# Altium schematic sheet Controller.SchDoc(Controller)
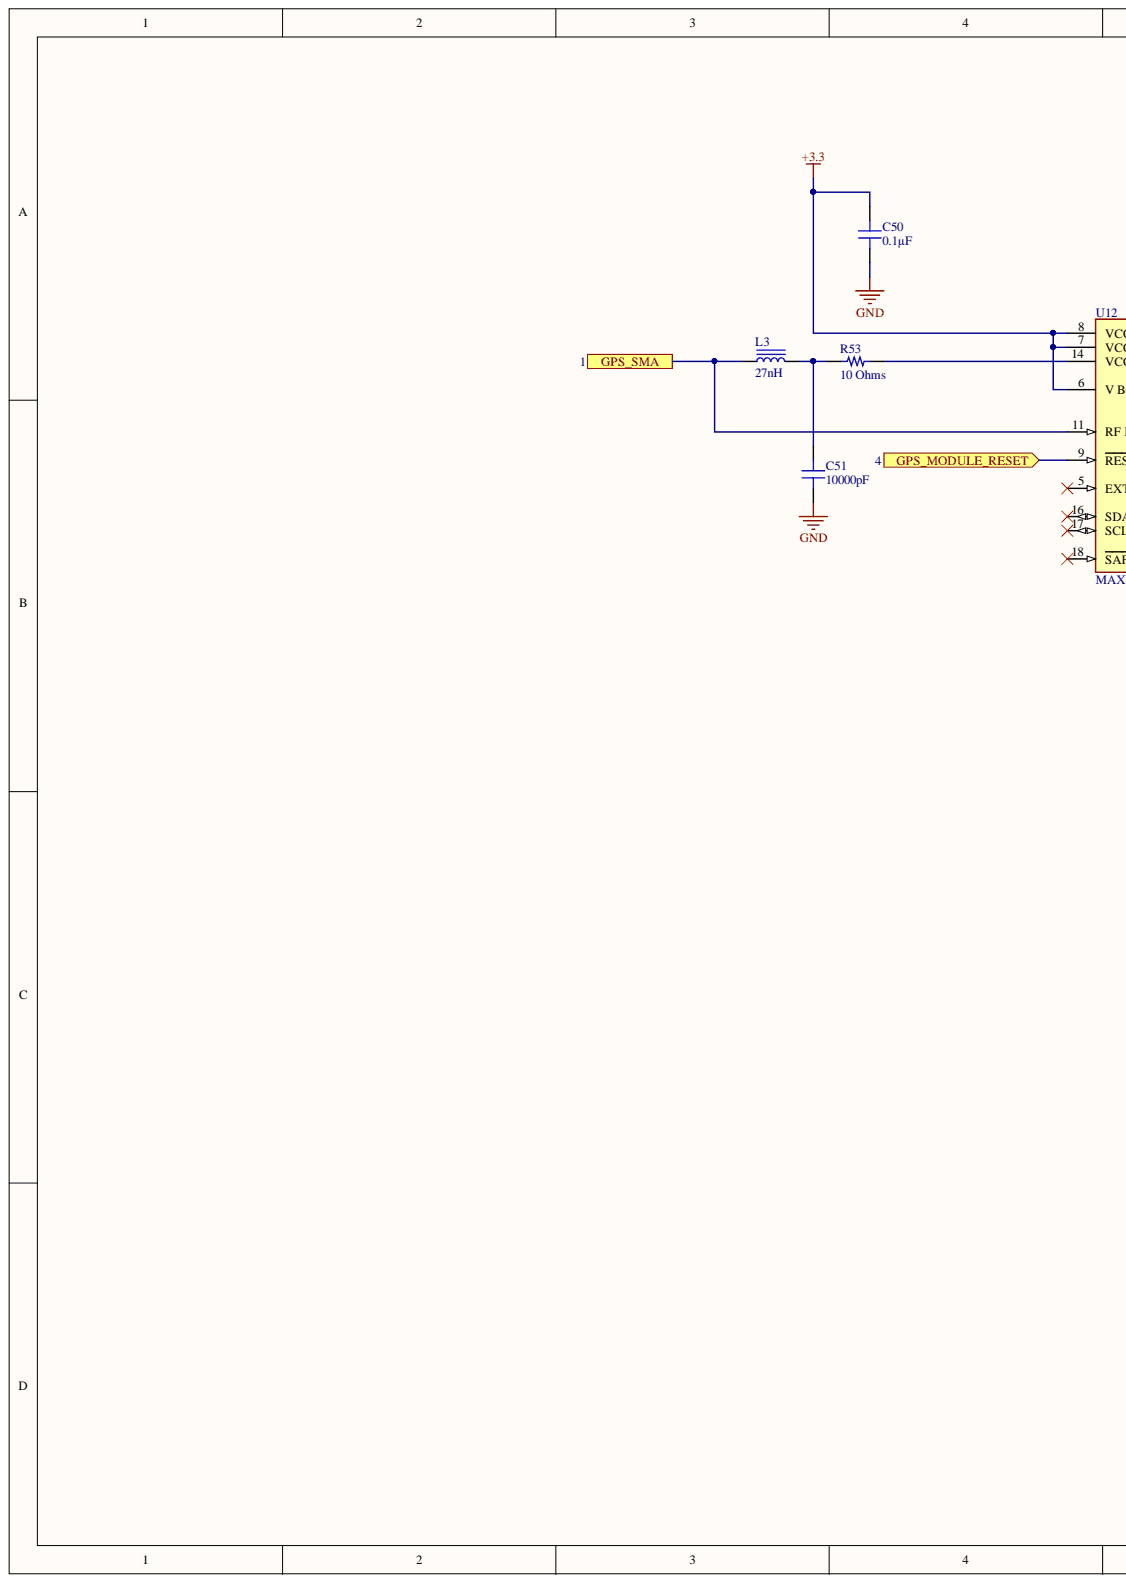
[diagram p0: Controller.SchDoc, left]
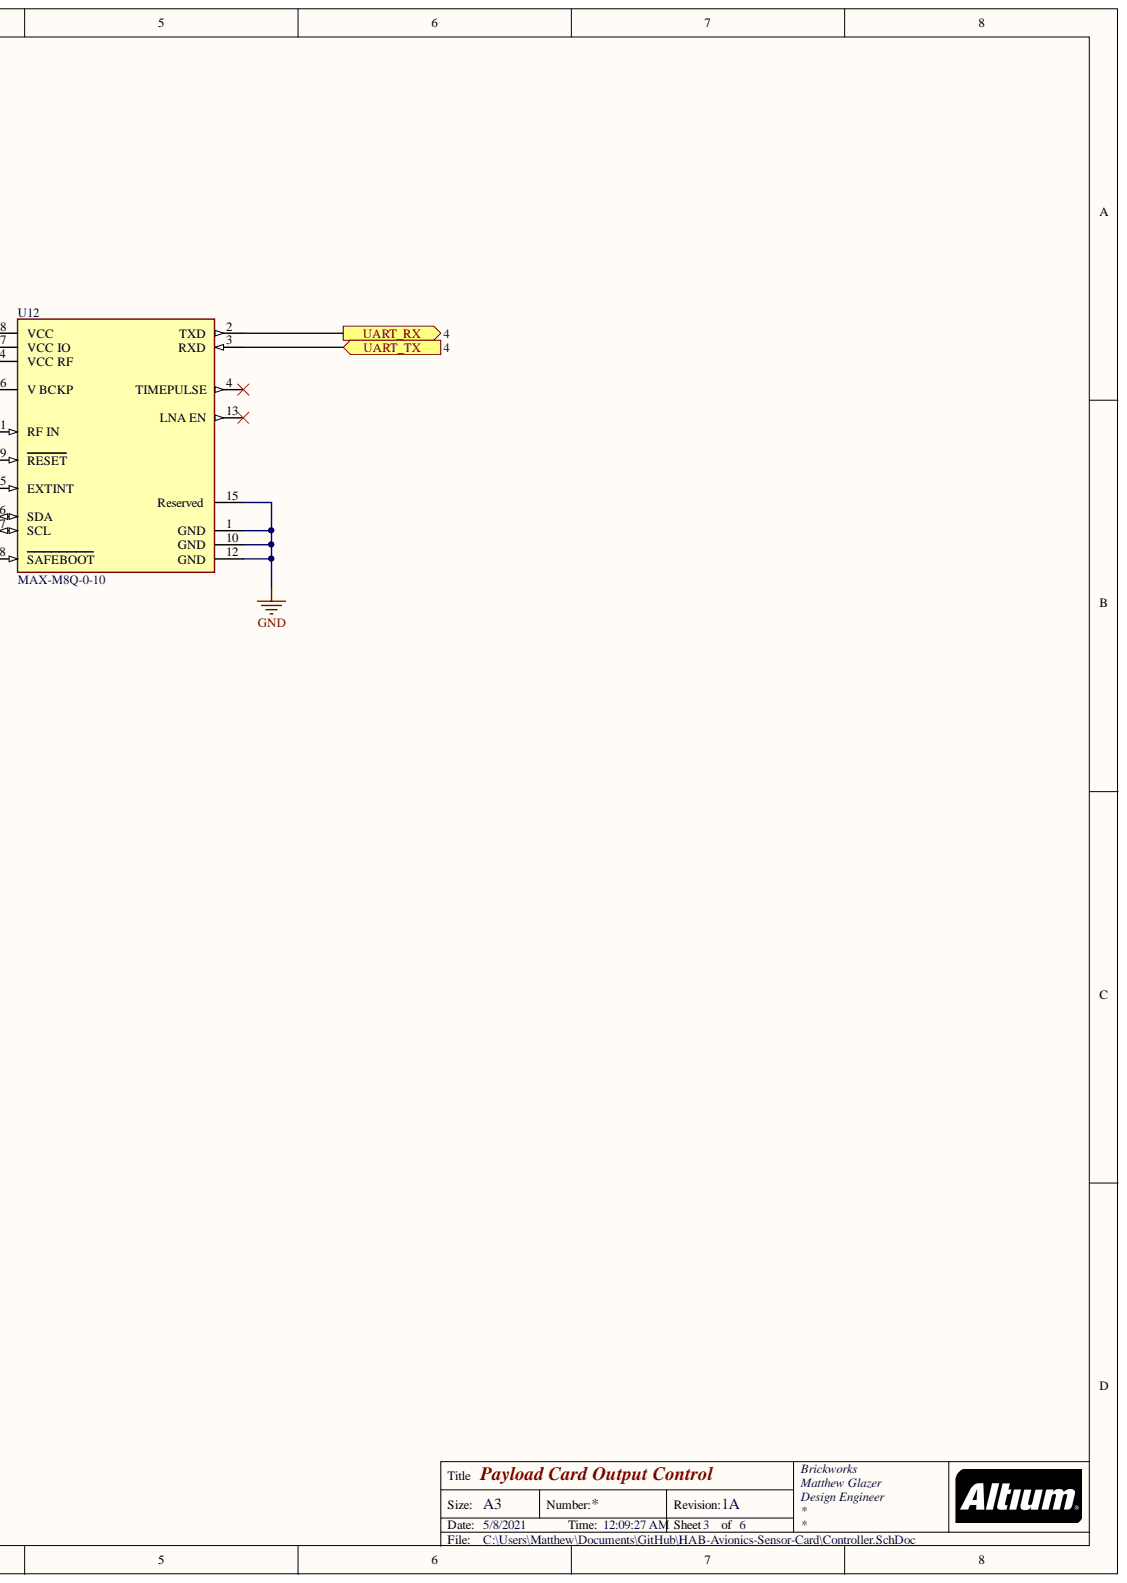
[diagram p1: Controller.SchDoc, right]

## Parts
C50  0.1µF  [CAP 0603_1608]
C51  10000pF  [CAP 0603_1608]
L3  27nH  [IND 0402_1005 RF WW]
R53  10 Ohms  [RES 0603_1608]
U12  MAX-M8Q-0-10  [FP-MAX-M8Q-0-10-MFG]

## Ports
GPS_MODULE_RESET, GPS_SMA, UART_RX, UART_TX

## Nets
+3.3: C50.1 U12.6(V_BCKP) U12.7(VCC_IO) U12.8(VCC)
GND: C50.2 C51.1 U12.1(GND) U12.10(GND) U12.12(GND) U12.15(Reserved)
GPS_MODULE_RESET: U12.9(RESET) ; port GPS_MODULE_RESET
GPS_SMA: L3.1 U12.11(RF_IN) ; port GPS_SMA
UART_RX: U12.2(TXD) ; port UART_RX
UART_TX: U12.3(RXD) ; port UART_TX
NetC51_2: C51.2 L3.2 R53.2
NetR53_1: R53.1 U12.14(VCC_RF)

Not connected: U12 4(TIMEPULSE) 5(EXTINT) 13(LNA_EN) 16(SDA) 17(SCL) 18(SAFEBOOT)

## Library data of the parts
C50 = CL10B104KA8WPNC: CAP CER 0.1UF 25V X7R 0603; Manufacturer: Samsung Electro-Mechanics; Tolerance: ±10%; Database Table Name: Capacitors - Ceramic - 0603; Voltage - Rated: 25V; Value: 0.1µF; Thickness (Max): 0.035" (0.90mm); Temperature Coefficient: X7R; Supplier Part Number 1: 1276-6717-1-ND; Supplier 1: DigiKey; Size / Dimension: 0.063" L x 0.031" W (1.60mm x 0.80mm); Series: CL; Ratings: AEC-Q200; Price: 0.02; Part Status: Active; Part Number: CL10B104KA8WPNC; PartId: 5631; Packaging: TapeAndReel; Package / Case: 0603 (1608 Metric); Operating Temperature: -55°C ~ 125°C; Mounting Type: Surface Mount, MLCC; Minimum Order: 1; Lifecycle Status: Active; Library Name: Celestial Altium Library - altium_library.DbLib; LastUpdated: 9/2/2020 1:03:30 AM; Features: Open Mode; Device Package: 0603 (1608 Metric); ComponentLink2URL: http://digikey.com/product-detail/en/samsung-electro-mechanics/CL10B104KA8WPNC/1276-6717-1-ND/5961576; ComponentLink2Description: DigiKey Link; ComponentLink1URL: http://www.samsungsem.com/kr/support/product-search/mlcc/CL10B104KA8WPNC.jsp; ComponentLink1Description: Datasheet; Component Kind: Standard; Capacitance: 0.1µF; Applications: Automotive
C51 = C0603S103K4RACTU: CAP CER 10000PF 16V X7R 0603; Manufacturer: KEMET; Tolerance: ±10%; Database Table Name: Capacitors - Ceramic - 0603; Voltage - Rated: 16V; Value: 10000pF; Thickness (Max): 0.034" (0.87mm); Temperature Coefficient: X7R; Supplier Part Number 1: 399-9135-1-ND; Supplier 1: DigiKey; Size / Dimension: 0.063" L x 0.031" W (1.60mm x 0.80mm); Series: SMD Comm X7R FE; Price: 0.07; Part Status: Active; Part Number: C0603S103K4RACTU; PartId: 3312; Packaging: TapeAndReel; Package / Case: 0603 (1608 Metric); Operating Temperature: -55°C ~ 125°C; Mounting Type: Surface Mount, MLCC; Minimum Order: 1; Lifecycle Status: Active; Library Name: Celestial Altium Library - altium_library.DbLib; LastUpdated: 9/2/2020 1:03:34 AM; Features: Floating Electrode; Device Package: 0603 (1608 Metric); ComponentLink2URL: http://digikey.com/product-detail/en/kemet/C0603S103K4RACTU/399-9135-1-ND/3522653; ComponentLink2Description: DigiKey Link; ComponentLink1URL: https://api.kemet.com/component-edge/download/datasheet/C0603S103K4RACTU.pdf; ComponentLink1Description: Datasheet; Component Kind: Standard; Capacitance: 10000pF; Applications: Boardflex Sensitive
L3 = LQW15AN27NG00D: FIXED IND 27NH 280MA 520 MOHM; Manufacturer: Murata Electronics; Type: Wirewound; Tolerance: ±2%; Shielding: Unshielded; Q @ Freq: 25 @ 250MHz; Material - Core: Non-Magnetic; Inductance Frequency - Test: 100MHz; Frequency - Self Resonant: 3.5GHz; DC Resistance (DCR): 520mOhm Max; Database Table Name: Inductors - RF; Value: 27nH; Supplier Part Number 1: 490-6792-1-ND; Supplier 1: DigiKey; Size / Dimension: 0.039" L x 0.020" W (1.00mm x 0.50mm); Series: LQW15; Price: 0.05; Part Status: Active; Part Number: LQW15AN27NG00D; PartId: 480; Packaging: TapeAndReel; Package / Case: 0402 (1005 Metric); Operating Temperature: -55°C ~ 125°C; Mounting Type: Surface Mount; Minimum Order: 1; Lifecycle Status: Active; Library Name: Celestial Altium Library - altium_library.DbLib; LastUpdated: 9/2/2020 1:22:25 AM; Inductance: 27nH; Height - Seated (Max): 0.024" (0.60mm); Device Package: 0402 (1005 Metric); Current Rating (Amps): 280mA; ComponentLink2URL: http://digikey.com/product-detail/en/murata-electronics/LQW15AN27NG00D/490-6792-1-ND/3845989; ComponentLink2Description: DigiKey Link; ComponentLink1URL: https://search.murata.co.jp/Ceramy/image/img/P02/JELF243A-0050.pdf; ComponentLink1Description: Datasheet; Component Kind: Standard
R53 = CRGCQ0603F10R: CRGCQ 0603 10R 1%; Manufacturer: TE Connectivity Passive Product; Temperature Coefficient: ±400ppm/°C; Power (Watts): 0.1W, 1/10W; Database Table Name: Resistors - Surface Mount - 0603; Value: 10 Ohms; Tolerance: ±1%; Supplier Part Number 1: A129664CT-ND; Supplier Device Package: 0603; Supplier 1: DigiKey; Size / Dimension: 0.063" L x 0.031" W (1.60mm x 0.80mm); Series: CRGCQ; Resistance: 10 Ohms; Ratings: AEC-Q200; Price: 0.10; Part Status: Active; Part Number: CRGCQ0603F10R; PartId: 23975; Packaging: CutTape; Package / Case: 0603 (1608 Metric); Operating Temperature: -55°C ~ 155°C; Number of Terminations: 2; Minimum Order: 1; Lifecycle Status: Active; Library Name: Celestial Altium Library - altium_library.DbLib; LastUpdated: 9/2/2020 1:09:39 AM; Height - Seated (Max): 0.022" (0.55mm); Features: Automotive AEC-Q200, Moisture Resistant; Device Package: 0603 (1608 Metric); Composition: Thick Film; ComponentLink2URL: http://digikey.com/product-detail/en/te-connectivity-passive-product/CRGCQ0603F10R/A129664CT-ND/8577496; ComponentLink2Description: DigiKey Link; ComponentLink1URL: https://www.te.com/commerce/DocumentDelivery/DDEController?Action=srchrtrv&DocNm=1773204-3&DocType=DS&DocLang=English; ComponentLink1Description: Datasheet; Component Kind: Standard
U12 = CMP-207130-000011-1: RF RCVR GNSS/GPS 1.575GHZ 18LCC; Manufacturer: u-blox; Sensitivity: -167dBm; Nominal Supply Current: 5.7-26mA; Min Supply Voltage: 2.7V; Max Supply Voltage: 3.6V; Interface: I2C,UART; Automotive Grade: Grade 3; Temperature Range Low: -40°C; Temperature Range High: +85°C; Temperature: -40°C to +85°C; RoHS: TRUE; Package: 0; Octopart: https://octopart.com/max-m8q-0-u-blox-54356790; Manufacturer Part Number: MAX-M8Q-0; Library Name: Altium Content Vault; Lead Free: Yes; Height: 2.7mm; Footprint Url: https://www.u-blox.com/en/docs/UBX-15030059; Digikey Description: RF RCVR GNSS/GPS 1.575GHZ 18LCC; Device Class L3: RF Receivers; Device Class L2: RF Semiconductors and Devices; Device Class L1: Integrated Circuits (ICs); ComponentLink2URL: https://www.u-blox.com/sites/default/files/MAX-M8-FW3_DataSheet_%28UBX-15031506%29.pdf; ComponentLink2Description: Datasheet; Component Kind: Standard; Category: UNK; Automotive: Yes
